# Altium schematic sheet LLP_Simple.SchDoc(LLP_Simple)
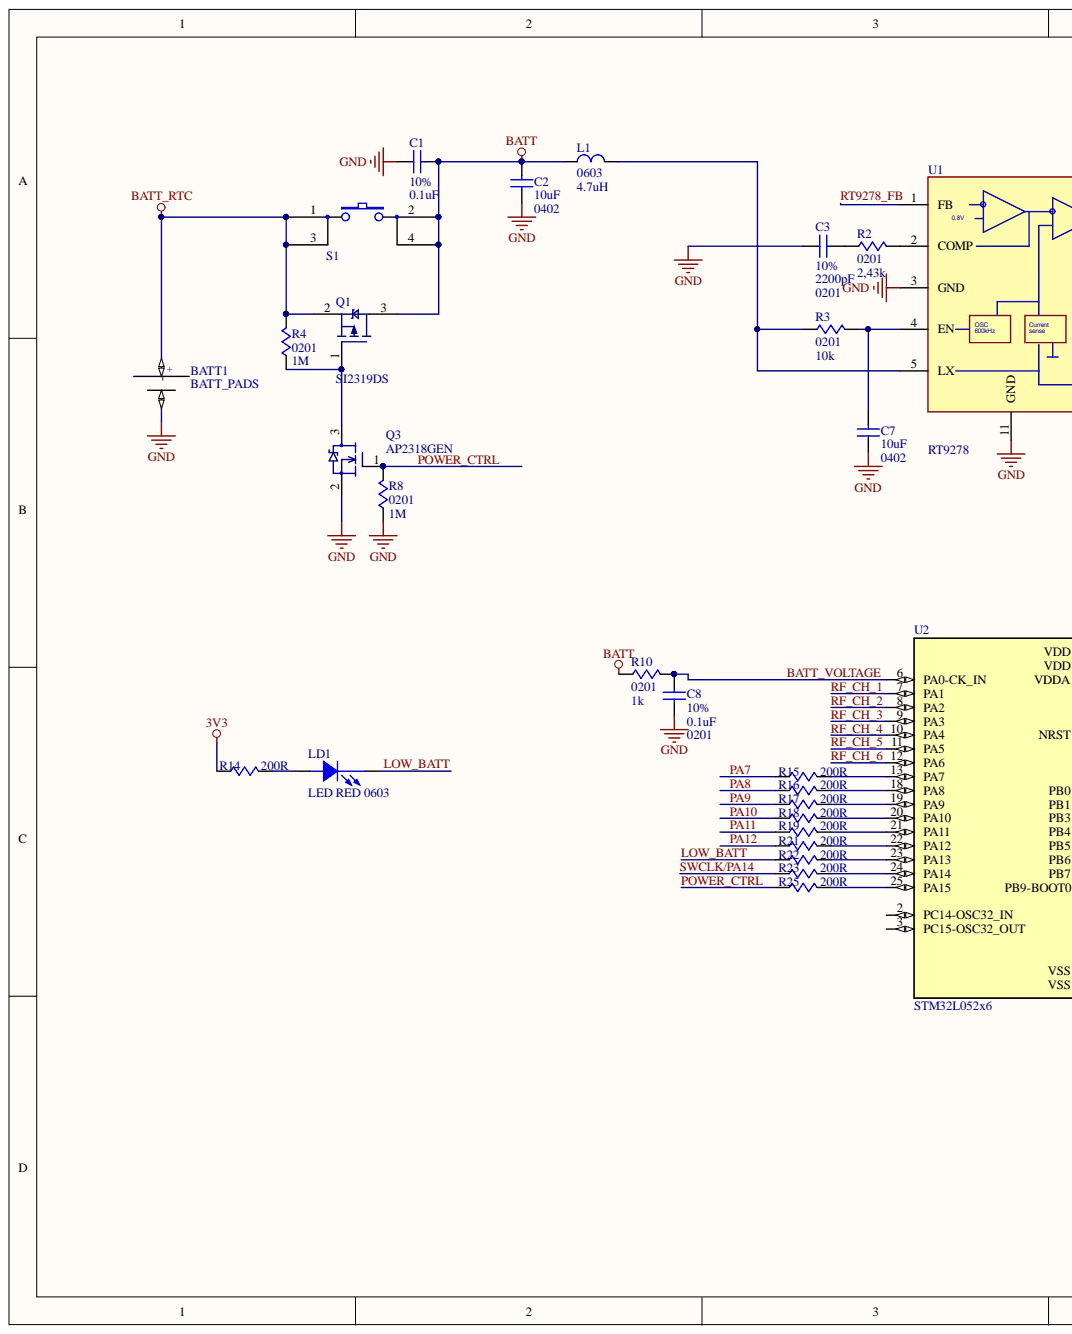
[diagram p0: LLP_Simple.SchDoc, left]
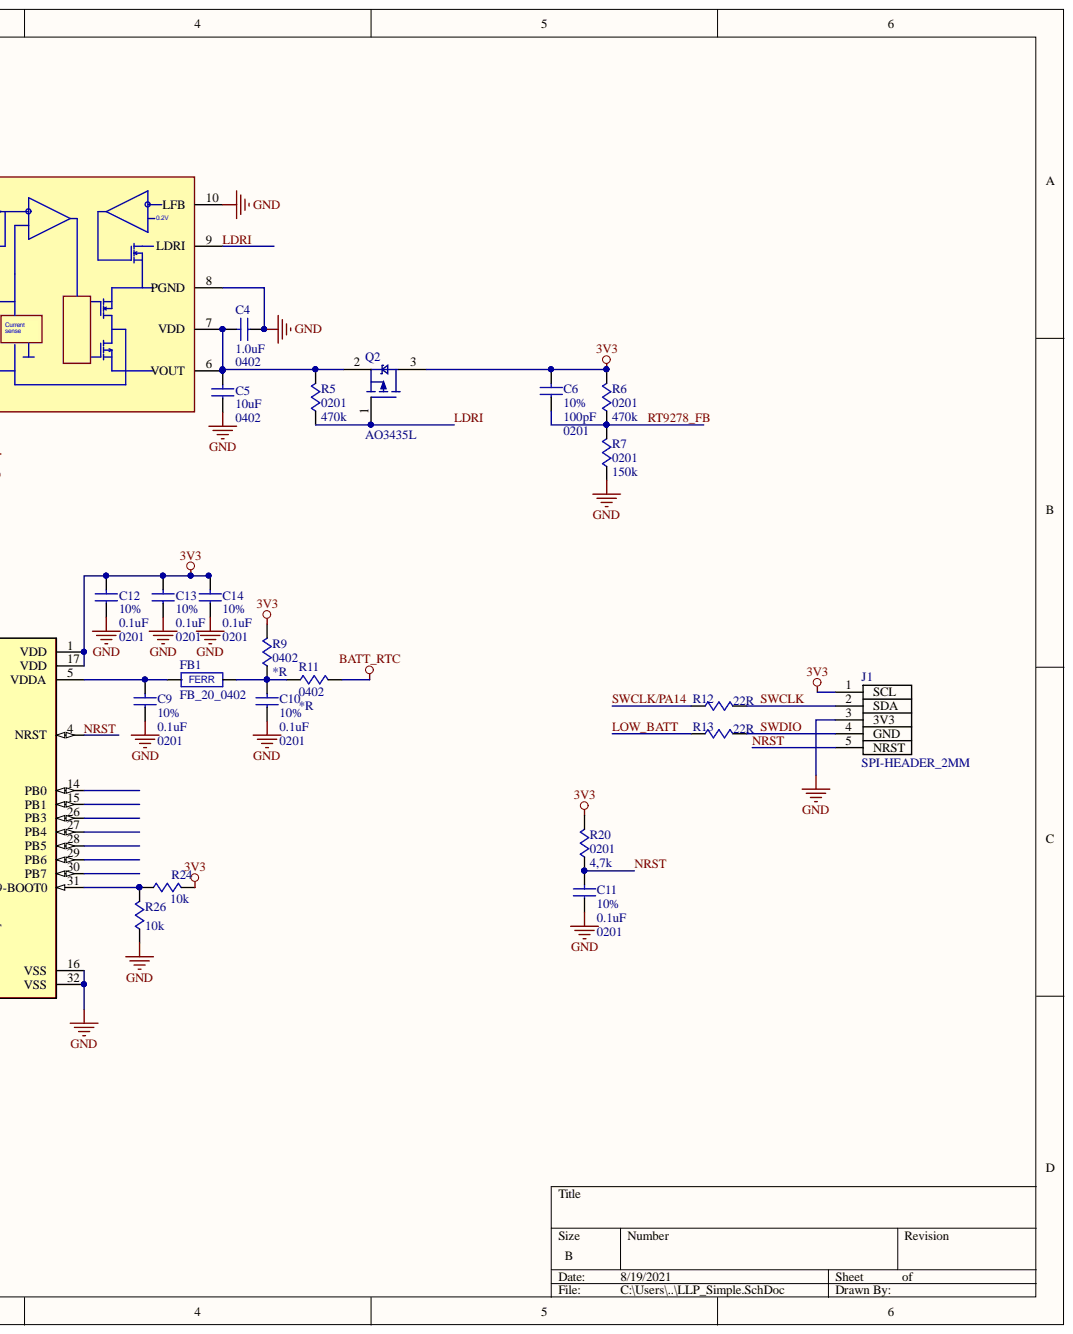
[diagram p1: LLP_Simple.SchDoc, right]

## Parts
BATT1  BATT_PADS  [BATT_PADS]
C1  value 0.1uF  TOLERANCE: 10%  [0201W10STC]  (hidden comment: a-Cap_0.1uF_1)
C2  value 10uF  [0402]  (hidden comment: a-Cap_10uF)
C3  value 2200pF  TOLERANCE: 10%  [0201W10STC]  (hidden comment: c-Cap_2200pF)
C4  value 1.0uF  [0402]  (hidden comment: a-Cap_1.0uF)
C5  value 10uF  [0402]  (hidden comment: a-Cap_10uF)
C6  value 100pF  TOLERANCE: 10%  FP: 0201  [0201W10STC]  (hidden comment: c-Cap_100pF)
C7  value 10uF  [0402]  (hidden comment: a-Cap_10uF)
C8  value 0.1uF  TOLERANCE: 10%  FP: 0201  [0201W10STC]  (hidden comment: a-Cap_0.1uF)
C9  value 0.1uF  TOLERANCE: 10%  FP: 0201  [0201W10STC]  (hidden comment: a-Cap_0.1uF)
C10  value 0.1uF  TOLERANCE: 10%  FP: 0201  [0201W10STC]  (hidden comment: a-Cap_0.1uF)
C11  value 0.1uF  TOLERANCE: 10%  FP: 0201  [0201W10STC]  (hidden comment: a-Cap_0.1uF)
C12  value 0.1uF  TOLERANCE: 10%  FP: 0201  [0201W10STC]  (hidden comment: a-Cap_0.1uF_1)
C13  value 0.1uF  TOLERANCE: 10%  FP: 0201  [0201W10STC]  (hidden comment: a-Cap_0.1uF_1)
C14  value 0.1uF  TOLERANCE: 10%  FP: 0201  [0201W10STC]  (hidden comment: a-Cap_0.1uF_1)
FB1  FB_20_0402  [0402]
J1  SPI-HEADER_2MM  [SPI_1x5-2MM_TOP]  (no BOM)
L1  value 4.7uH  [0603]  (hidden comment: Inductor_0603_4.7uH)
LD1  LED RED 0603  COLOR: RED  [0603LED_RED]
Q1  SI2319DS  [SOT23-A]
Q2  AO3435L  [SOT23-A]
Q3  AP2318GEN  [SOT23-A]
R2  value 2,43k  FP: 0201  [0201W10ST]  (hidden comment: R_0201_2430)
R3  value 10k  FP: 0201  [0201W10ST]  (hidden comment: R_0201_10000_1)
R4  value 1M  FP: 0201  [0201W10ST]  (hidden comment: R_0201_1000000)
R5  value 470k  FP: 0201  [0201W10ST]  (hidden comment: R_0201_470000)
R6  value 470k  FP: 0201  [0201W10ST]  (hidden comment: R_0201_470000)
R7  value 150k  FP: 0201  [0201W10ST]  (hidden comment: R_0201_150000)
R8  value 1M  FP: 0201  [0201W10ST]  (hidden comment: R_0201_1000000)
R9  R_0402  value *R  FP: 0402  [R0402]
R10  value 1k  FP: 0201  [0201W10ST]  (hidden comment: R_0201_1000)
R11  R_0402  value *R  FP: 0402  [R0402]
R12  value 22R  [0201W10ST]  (hidden comment: R_0201_22)
R13  value 22R  [0201W10ST]  (hidden comment: R_0201_22)
R14  value 200R  [0201W10ST]  (hidden comment: R_0201_200)
R15  value 200R  [0201W10ST]  (hidden comment: R_0201_200)
R16  value 200R  [0201W10ST]  (hidden comment: R_0201_200)
R17  value 200R  [0201W10ST]  (hidden comment: R_0201_200)
R18  value 200R  [0201W10ST]  (hidden comment: R_0201_200)
R19  value 200R  [0201W10ST]  (hidden comment: R_0201_200)
R20  value 4,7k  FP: 0201  [0201W10ST]  (hidden comment: R_0201_4700_1)
R21  value 200R  [0201W10ST]  (hidden comment: R_0201_200)
R22  value 200R  [0201W10ST]  (hidden comment: R_0201_200)
R23  value 200R  [0201W10ST]  (hidden comment: R_0201_200)
R24  value 10k  [0201W10ST]  (hidden comment: R_0201_10000_1)
R25  value 200R  [0201W10ST]  (hidden comment: R_0201_200)
R26  value 10k  [0201W10ST]  (hidden comment: R_0201_10000_1)
S1  SW_PUSHBUT_RA_LowProfile_SMD  [KFC-A07-35]  (value not drawn)
U1  RT9278  [WDFN-10L_3X3]
U2  STM32L052x6  [QFP80P900X900X160-32N]

## Nets
3V3: C6.2 C12.2 C13.2 C14.2 J1.1(SCL) Q2.3 R6.2 R9.2 R14.1 R20.2 R24.2 U2.1(VDD) U2.17(VDD)
BATT: C1.2 C2.2 L1.1 Q1.3 R10.1 S1.2 S1.4
BATT_RTC: BATT1.1 Q1.2 R4.2 R11.2 S1.1 S1.3
GND: BATT1.2 C1.1 C2.1 C3.1 C4.1 C5.1 C7.1 C8.1 C9.1 C10.1 C11.1 C12.1 C13.1 C14.1 J1.3(3V3) Q3.2 R7.1 R8.1 R26.1 U1.3(GND) U1.8(PGND) U1.10(LFB) U1.11(GND) U2.16(VSS) U2.32(VSS)
BATT_VOLTAGE: C8.2 R10.2 U2.6(PA0-CK_IN)
LDRI: Q2.1 R5.1 U1.9(LDRI)
LOW_BATT: LD1.1 R13.1 R22.1
NRST: C11.2 J1.5(NRST) R20.1 U2.4(NRST)
PA7: R15.1
PA8: R16.1
PA9: R17.1
PA10: R18.1
PA11: R19.1
PA12: R21.1
POWER_CTRL: Q3.1 R8.2 R25.1
RF_CH_1: U2.7(PA1)
RF_CH_2: U2.8(PA2)
RF_CH_3: U2.9(PA3)
RF_CH_4: U2.10(PA4)
RF_CH_5: U2.11(PA5)
RF_CH_6: U2.12(PA6)
RT9278_FB: C6.1 R6.1 R7.2 U1.1(FB)
SWCLK: J1.2(SDA) R12.2
SWCLK/PA14: R12.1 R23.1
SWDIO: J1.4(GND) R13.2
NetC3_2: C3.2 R2.1
NetC4_2: C4.2 C5.2 Q2.2 R5.2 U1.6(VOUT) U1.7(VDD)
NetC7_2: C7.2 R3.2 U1.4(EN)
NetC9_2: C9.2 FB1.2 U2.5(VDDA)
NetC10_2: C10.2 FB1.1 R9.1 R11.1
NetL1_2: L1.2 R3.1 U1.5(LX)
NetLD1_2: LD1.2 R14.2
NetQ1_1: Q1.1 Q3.3 R4.1
NetR2_2: R2.2 U1.2(COMP)
NetR15_2: R15.2 U2.13(PA7)
NetR16_2: R16.2 U2.18(PA8)
NetR17_2: R17.2 U2.19(PA9)
NetR18_2: R18.2 U2.20(PA10)
NetR19_2: R19.2 U2.21(PA11)
NetR21_2: R21.2 U2.22(PA12)
NetR22_2: R22.2 U2.23(PA13)
NetR23_2: R23.2 U2.24(PA14)
NetR24_1: R24.1 R26.2 U2.31(PB9-BOOT0)
NetR25_2: R25.2 U2.25(PA15)

Not connected: U2 2(PC14-OSC32_IN) 3(PC15-OSC32_OUT) 14(PB0) 15(PB1) 26(PB3) 27(PB4) 28(PB5) 29(PB6) 30(PB7)

## Library data of the parts
C1 = a-Cap_0.1uF_1: Capacitor 0.1uF, 10V, ±10%, X5R, ROHS C, 0201; PART#: GRM033R61A104KE84D; WB: 0; PID: 40882; MF: Murata Manufacturing Co.; FP: 0201; ACCEPT: CROSS REFERENCE; VOLTAGE: 10V; VALUE: 0.1uF; TYPE: X5R; SMD: 1; PRICE: 0; Library Name: Capacitors 0201.IntLib; HELPURL: http://kravad.mt.lv/kravad/files/BVsbTv9U8CiPM9dwUBwcFsN5RHJtYnyb; DESC: Capacitor 0.1uF, 10V, ±10%, X5R, ROHS C, 0201; Component Kind: Standard
C2 C5 C7 = a-Cap_10uF: Capacitor 10uF, 20%, 4V, X5R, 0402; PART#: CL05A106MR5NRNC; WB: 0; QTY_TILL: 10000000; QTY_FROM: 20000; PID: 19038; MF: Samsung Electronic Co. Ltd.; ACCEPT: CROSS REFERENCE; VOLTAGE: 4V; TYPE: X5R; TOLERANCE: 20%; SMD: 1; PRICE: 0,081893; Library Name: Capacitors 0402.IntLib; HELPURL: http://kravad.mt.lv/kravad/files/iaRWWxhAK7CnfK4Chj5pL6NMzcT8PkRr; FP: 0402; DATE_TILL: 01.01.2099; DATE_FROM: 29.01.2018; COMPONENTLINK1URL: http://kravad.mt.lv/kravad/files/6daL9vzohBPZGKVZjjDwDEy5wDEwzMB3; COMPONENTLINK1DESCRIPTION: Selected Part_Datasheet 25264; Component Kind: Standard
C3 = c-Cap_2200pF: Capacitor 2200pF 10% 10V X7R 0201; PART#: GRM033R71A222KA01D; WB: 0; QTY_TILL: 60000; PID: 23043; MF: Murata manufacturing Co.; FP: 0201; ACCEPT: CROSS REFERENCE; VOLTAGE: 10V; TYPE: X7R; SMD: 1; QTY_FROM: 60000; PRICE: 0,001521; Library Name: Capacitors 0201.IntLib; DESC: Capacitor 2200pF 10% 10V X7R 0201; DATE_TILL: 01.01.2099; DATE_FROM: 03.04.2018; Component Kind: Standard
C4 = a-Cap_1.0uF: Capacitor 1.0uF, +/-10%, 6.3V, X5R, RoHS C, 0402; PART#: GRM155R60J105KE19D; WB: 0; TOLERANCE: 10%; QTY_TILL: 10000000; QTY_FROM: 2000000; PID: 6838; NOTE: MOQ 2mlj; MF: Murata manufacturing Co.; ACCEPT: CROSS REFERENCE; VOLTAGE: 6.3V; TYPE: X5R; SMD: 1; PRICE: 0,001872; Library Name: Capacitors 0402.IntLib; HELPURL: http://kravad.mt.lv/kravad/files/kuBkxiARDXG2psXRDAHieVKY5sCALpVz; FP: 0402; DATE_TILL: 01.01.2099; DATE_FROM: 06.04.2018; COMPONENTLINK1URL: http://kravad.mt.lv/kravad/files/257sap3yhtdvZcVsAgb8mdvM3T5xNu3J; COMPONENTLINK1DESCRIPTION: Selected Part_Datasheet 9780; Component Kind: Standard
C6 = c-Cap_100pF: Capacitor 100pF 10% 25V X7R 0201; PART#: GRM033R71E101KA01D; WB: 0; QTY_TILL: 90000; QTY_FROM: 15000; PID: 23829; MF: Murata Electronics; ACCEPT: CROSS REFERENCE; VOLTAGE: 25V; TYPE: X7R; SMD: 1; PRICE: 0,001989; Library Name: Capacitors 0201.IntLib; HELPURL: http://kravad.mt.lv/kravad/files/iC8YYVeHCdxRH5emSVpo8Ygrt2GVtDPw; DESC: Capacitor 100pF 10% 25V X7R 0201; DATE_TILL: 01.01.2099; DATE_FROM: 30.11.2017; Component Kind: Standard
C8-C11 = a-Cap_0.1uF: Capacitor 0.1uF, 6.3V, +/-10%, X5R, ROHS C, 0201; PART#: CC0201KRX5R5BB104; WB: 0; QTY_TILL: 15000000; QTY_FROM: 15000; PID: 6924; NOTE: ðâda cena PID: 37191; PID: 9570 piedâvâ par 0,00083 EUR, bet stockiem pavisam cita cena; MF: Yageo Corporation; ACCEPT: CROSS REFERENCE; VOLTAGE: 6.3V; TYPE: X5R; SMD: 1; PRICE: 0,001451; Library Name: Capacitors 0201.IntLib; HELPURL: http://kravad.mt.lv/kravad/files/4NygfvJ9AbZBmRAwKHTs5Xb6eDXiVbh5; DESC: Capacitor 0.1uF, 6.3V, +/-10%, X5R, ROHS C, 0201; DATE_TILL: 01.01.2099; DATE_FROM: 13.03.2018; COMPONENTLINK1URL: http://kravad.mt.lv/kravad/files/SwV6noVgiHHgGg9jUBLP9PeddchnipMR; COMPONENTLINK1DESCRIPTION: Selected Part_Datasheet 30000; Component Kind: Standard
C12-C14 = a-Cap_0.1uF_1: Capacitor 0.1uF, 10V, ±10%, X5R, ROHS C, 0201; PART#: GRM033R61A104KE84D; WB: 0; PID: 40882; MF: Murata Manufacturing Co.; ACCEPT: CROSS REFERENCE; VOLTAGE: 10V; TYPE: X5R; SMD: 1; PRICE: 0; Library Name: Capacitors 0201.IntLib; HELPURL: http://kravad.mt.lv/kravad/files/BVsbTv9U8CiPM9dwUBwcFsN5RHJtYnyb; DESC: Capacitor 0.1uF, 10V, ±10%, X5R, ROHS C, 0201; Component Kind: Standard
FB1 = FB20_0402: Ferrite Bead 20 Ohm 3.8A 11mOhm 0402; PART#: BLM15KD200SN1D; WB: 1; VALUE: 20 Ohm / 100MHz, 3.8A 11mOhm; PID: 44993; MF: Murata manufacturing Co.; ACCEPT: ENGINEER; SMD: 1; PRICE: 0; Library Name: Ferrite beads.IntLib; HELPURL: http://kravad.mt.lv/kravad/files/2JhwyktKnWtzBAidLNHSTryBpR483vxA; FP: 0402; DESC: Ferrite Bead 20 Ohm 3.8A 11mOhm 0402; Component Kind: Standard
J1 = I2C-HEADER_5P_2MM: WB: 0; QTY_FROM: 1; DESC: Straight Pin Header T/H, 2x5pins, 2 mm Pitch, Vertical, RoHS; ACCEPT: CROSS REFERENCE; SMD: 0; Library Name: Connectors.IntLib; Description: SPI-HEADER_2mm
L1 = Inductor_0603_4.7uH: Inductor 4.7uH 0603 +/-20% 500mA 240mOhm; PART#: GLFR1608T4R7M-LR; WB: 1; TOLERANCE: 20%; PID: 48120; MF: TDK; DESC: Inductor 4.7uH ±20% 500mA 240mOhm 0603; ACCEPT: ENGINEER; SMD: 1; PRICE: 0; Library Name: Chip Inductors.IntLib; HELPURL: http://kravad.mt.lv/kravad/files/88e5gReByxPbffScdby2FDNCraayXvZR; FP: 0603; Component Kind: Standard
LD1 = LED_0603_R: 0603 Ultra Bright Red Led, 54mcd; PART#: LTST-C191KRKT; WB: 1; VOLTAGE: Vf=2.0V; QTY_TILL: 50000; QTY_FROM: 15000; PID: 24352; MF: Lite-On Singapore Pte Ltd (istais); DESC: Ultrabright LED Red 631nm 54mcd/130deg 0603 SMD; ACCEPT: ENGINEER; VALUE: 54mcd; SMD: 1; PRICE: 0,01225; Library Name: LED.IntLib; HELPURL: http://kravad.mt.lv/kravad/files/nekJghJthpkvE5Kb8s7ThmJZaCwRfK2K; FP: 24352; DATE_TILL: 17.03.2088; DATE_FROM: 19.01.2015; Component Kind: Standard
Q1 = SI2319DS: P_Channel Mosfet 40V 2.3A,SOT-23; PART#: SI2319DS-1-E3; WB: 1; QTY_TILL: 30000; QTY_FROM: 20000; PID: 12268; MF: Vishay Intertechnology, Inc.; FP: SOT-23A; DESC: Trans MOSFET P-Ch 40V 2.3A 3-Pin SOT23 T/R; ACCEPT: ENGINEER; SMD: 1; PRICE: 0,024; Library Name: MOSFET.IntLib; HELPURL: http://kravad.mt.lv/kravad/files/e8naTUaretvJSfZ45yGs3VJJkwzhhtiZ; DATE_TILL: 01.01.2099; DATE_FROM: 18.03.2019; COMPONENTLINK1URL: http://kravad.mt.lv/kravad/files/fe59YtKjEnMsDiWyftmH24ChVH86m3v6; COMPONENTLINK1DESCRIPTION: Selected Part_Datasheet 47977; Component Kind: Standard
Q2 = AO3435L: P_Channel Mosfet 20V 3.5A, 70mOhm SOT-23; WB: 1; QTY_TILL: 30000; QTY_FROM: 20000; PID: 11387; MF: Alpha & Omega; FP: SOT-23A; DESC: MOSFET P-Ch -20V -3.5A 70mOhm 1.5V level SOT-23 Halogen free; ACCEPT: ENGINEER; SMD: 1; PRICE: 0,024; PART#: AO3435L; Library Name: MOSFET.IntLib; HELPURL: http://kravad.mt.lv/kravad/files/2LSANV8N3t7pjEFfKDSiZS79y5YLWPPR; DATE_TILL: 01.01.2099; DATE_FROM: 18.03.2019; COMPONENTLINK1URL: http://kravad.mt.lv/kravad/files/fe59YtKjEnMsDiWyftmH24ChVH86m3v6; COMPONENTLINK1DESCRIPTION: Selected Part_Datasheet 47977; Component Kind: Standard
Q3 = AP2318GEN: MOSFET N-Ch 30V 500mA SOT23; WB: 1; PID: 16553; MF: Advanced Power Electronics Corp.; DESC: MOSFET N-Ch 30V 500mA 1.5 Ohm 2.5V level SOT-23; ACCEPT: ENGINEER; VOLTAGE: 30V; SMD: 1; PART#: AP2318GEN; Library Name: MOSFET.IntLib; FP: SOT23-A; Component Kind: Standard
R2 = R_0201_2430: Resistor 2,43k, 1%, 1/20W, 0201; PART#: RC0201FR-072K43L; WB: 0; VOLTAGE: 15V; TOLERANCE: +-1%; Temp RANGE: –55 °C to +155 °C; PID: 43689; MF: Yageo Corporation; DESC: Resistor 2,43k 1% 0201; ACCEPT: CROSS REFERENCE; SMD: 1; Library Name: Resistors 0201.IntLib; Component Kind: Standard
R3 R24 R26 = R_0201_10000_1: Resistor 10k 1% 1/20W 0201; PART#: CR-01FL0---10K; WB: 0; VOLTAGE: 15V; TOLERANCE: +-1%; Temp RANGE: –55 °C to +155 °C; PID: 37461; MF: Vishay Electronic GmbH; DESC: Resistor 10 kOhm, 1%, 1/20W, 0201; ACCEPT: CROSS REFERENCE; SMD: 1; Library Name: Resistors 0201.IntLib; Component Kind: Standard
R4 R8 = R_0201_1000000: Resistor 1M 1% 0201; PART#: RC0201FR-07100KL; WB: 0; VOLTAGE: 25V; TOLERANCE: +-1%; Temp RANGE: –55 °C to +155 °C; QTY_TILL: 10000; PID: 20330; MF: Vishay Intertechnology, Inc.; ACCEPT: CROSS REFERENCE; SMD: 1; QTY_FROM: 10000; PRICE: 0,005011; Library Name: Resistors 0201.IntLib; DESC: Resistor 1M 1% 0201; Component Kind: Standard
R5 R6 = R_0201_470000: Resistor 470k, 1%, 1/20W, 0201; PART#: CR-01FL0--470K; WB: 0; VOLTAGE: 15V; TOLERANCE: +-1%; Temp RANGE: –55 °C to +155 °C; PID: 34390; MF: Viking Tech Corporation; DESC: Resistor 470 kOhm, 1%, 1/20W, 0201; ACCEPT: CROSS REFERENCE; SMD: 1; Library Name: Resistors 0201.IntLib; Component Kind: Standard
R7 = R_0201_150000: Resistor 150k 1% 0201; PART#: RC0201FR-07150KL; WB: 0; VOLTAGE: 15V; TOLERANCE: +-1%; Temp RANGE: –55 °C to +155 °C; PID: 26040; MF: Yageo Corporation; ACCEPT: CROSS REFERENCE; SMD: 1; PRICE: 0; Library Name: Resistors 0201.IntLib; HELPURL: http://kravad.mt.lv/Datasheet/Datasheet?did=685&code=PYuRC020151RoHSL5.pdf; DESC: Resistor 150k 1% 0201; Component Kind: Standard
R9 R11 = R_0402: template; WB: 0; VOLTAGE: 50V; TOLERANCE: +-1%; Temp RANGE: –55 °C to +155 °C; MF: Yageo Corporation; ACCEPT: CROSS REFERENCE; TYPE: GPTFR; SMD: 1; Library Name: Resistors 0402.IntLib; Component Kind: Standard
R10 = R_0201_1000: Resistor 1k, 1%, 1/20W, 0201; PART#: RC0201FR-071KL; WB: 0; VOLTAGE: 15V; TOLERANCE: +-1%; Temp RANGE: –55 °C to +155 °C; QTY_TILL: 10000; PID: 12535; MF: Yageo Corporation; DESC: Resistor 1k 1% 0201; DATE_TILL: 2099.01.01.; DATE_FROM: 2010.11.16.; ACCEPT: CROSS REFERENCE; SMD: 1; QTY_FROM: 10000; PRICE: 0,004507; Library Name: Resistors 0201.IntLib; Component Kind: Standard
R12 R13 = R_0201_22: Resistor 22R, 1%, 1/20W, 0201; PART#: ERJ-1GEF22R0C; WB: 0; VOLTAGE: 15V; TOLERANCE: +-1%; Temp RANGE: –55 °C to +155 °C; QTY_TILL: 1000000; QTY_FROM: 10000; PID: 9216; MF: Panasonic; DESC: Resistor 0201 22,0R 1% 0,05W TK200; DATE_TILL: 2099.01.01.; DATE_FROM: 2014.09.08.; ACCEPT: CROSS REFERENCE; SMD: 1; PRICE: 0,000982; Library Name: Resistors 0201.IntLib; HELPURL: http://kravad.mt.lv/kravad/files/aAJwLSahD5HSJKUHWtTJ5FMWkKT8YoCK; COMPONENTLINK1URL: http://kravad.mt.lv/kravad/files/e9PH6u8tR3AzbwpgLxdwCMFEDCaTXF7E; COMPONENTLINK1DESCRIPTION: Selected Part_Datasheet 22796; Component Kind: Standard
R14-R19 R21-R23 R25 = R_0201_200: Resistor 200R, 1%, 1/20W, 0201; PART#: RC0201FR-07200RL; WB: 0; VOLTAGE: 15V; TOLERANCE: +-1%; Temp RANGE: –55 °C to +155 °C; QTY_TILL: 100000; QTY_FROM: 10000; PID: 20048; NOTE: 2015-04-27 20K stock; MF: Yageo Corporation; DESC: Resistor 200 Ohm, 1%, 1/20W, 0201; DATE_TILL: 2099.01.01.; DATE_FROM: 2015.04.28.; ACCEPT: CROSS REFERENCE; SMD: 1; PRICE: 0,001765; Library Name: Resistors 0201.IntLib; Component Kind: Standard
R20 = R_0201_4700_1: Resistor 4,7k 1% 0201; PART#: RMCF0201FT4K70; WB: 0; VOLTAGE: 15V; TOLERANCE: +-1%; Temp RANGE: –55 °C to +155 °C; PID: 43389; MF: Stackpole Electronics, Inc.; ACCEPT: CROSS REFERENCE; SMD: 1; Library Name: Resistors 0201.IntLib; DESC: Resistor 4,7k 1% 0201; Component Kind: Standard
S1 = SW_PUSHBUT_RA_LowProfile_SMD: Right angle SMT tact switch_Low_Profile DragonWell; WB: 1; QTY_TILL: 10000; QTY_FROM: 1000; PID: 43242; MF: Dragon Well Electronics Co.,Ltd.; ACCEPT: ENGINEER; SMD: 1; PRICE: 0,072; PART#: KFC-A07-35; Library Name: Switches.IntLib; HELPURL: http://kravad.mt.lv/kravad/files/fMzDW545CeFFtR3XtK29xHtU3dt8WaBE; DESC: Dragon Well Electronics Co.,Ltd.; DATE_TILL: 01.01.2099; DATE_FROM: 21.03.2019; Component Kind: Standard
U1 = RT9278_PQV: Step up converter Vin=1.5...5.5V, Vout_max=5.5V, Ilim=2A, f=0.65MHz; PART#: RT9278GQV; WB: 1; QTY_FROM: 4500; PID: 7359; NOTE: MOQ/MPQ is 4.5K/box (=3x 1.5K/reel).; MF: Richtek Technology Corporation; DESC: Step up converter Vin=1.5...5.5V, Vout_max=5.5V, Ilim=2A, f=0.65MHz, Tape&Reel, RoHS; ACCEPT: ENGINEER; SMD: 1; PRICE: 0,27; Library Name: Power Supply ICs.IntLib; HELPURL: http://kravad.mt.lv/kravad/files/oZkCFVhaZ679reajveBrKfyFuK4UEAET; DATE_TILL: 01.01.2099; DATE_FROM: 01.02.2013; Component Kind: Standard
U2 = STM32L052x6: Ultra-low-power 32-bit MCU Arm®-based Cortex®-M0+, up to 64 KB  Flash memory, 8 KB SRAM, 2 KB EEPROM, USB, ADC, DAC; MP: STM32L011K4T6; MF: STMicroelectronics; SnapEDA_Link: https://www.snapeda.com/parts/STM32L011K4T6/STMicroelectronics/view-part/?ref=snap; Price: None; Package: LQFP-32 STMicroelectronics; Component Kind: Standard; Availability: Unavailable; Library Name: My_Altiu_Lib.SchLib
BATT1 = BATT_PADS: Component Kind: Standard; Library Name: My_Altiu_Lib.SchLib
